# Altium schematic sheet FPGA_IO.SchDoc(FPGA_IO)
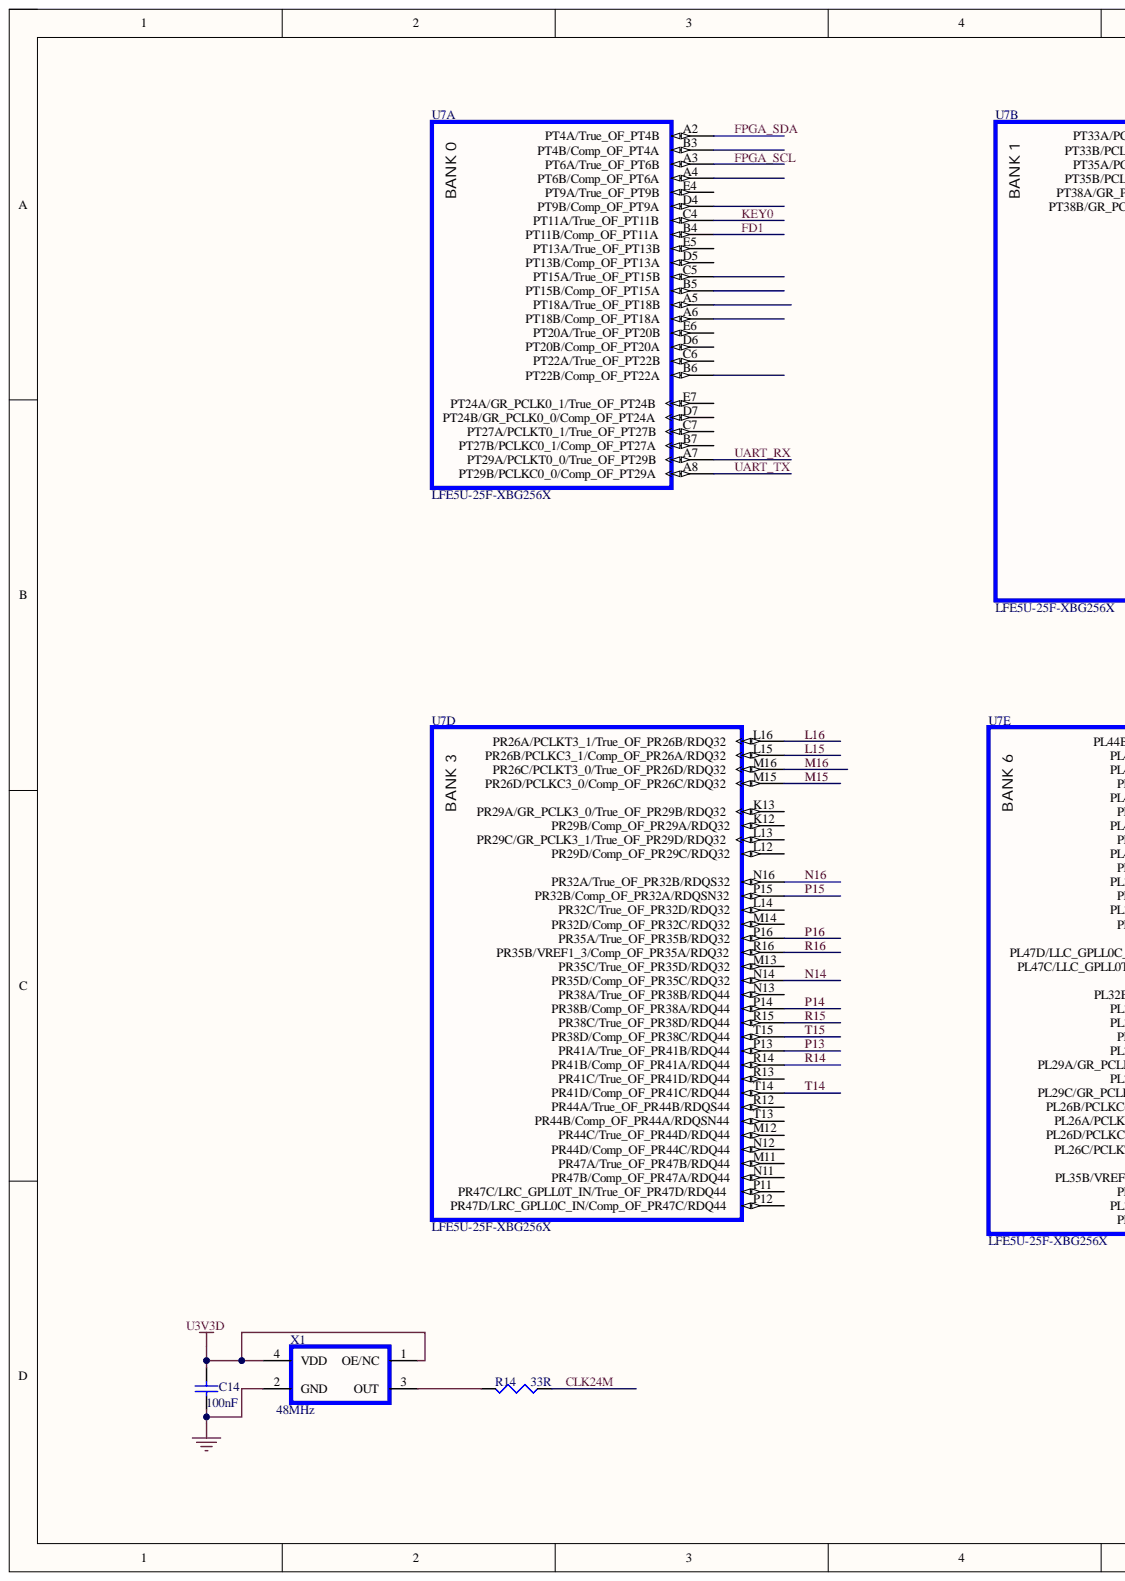
[diagram p0: FPGA_IO.SchDoc, left]
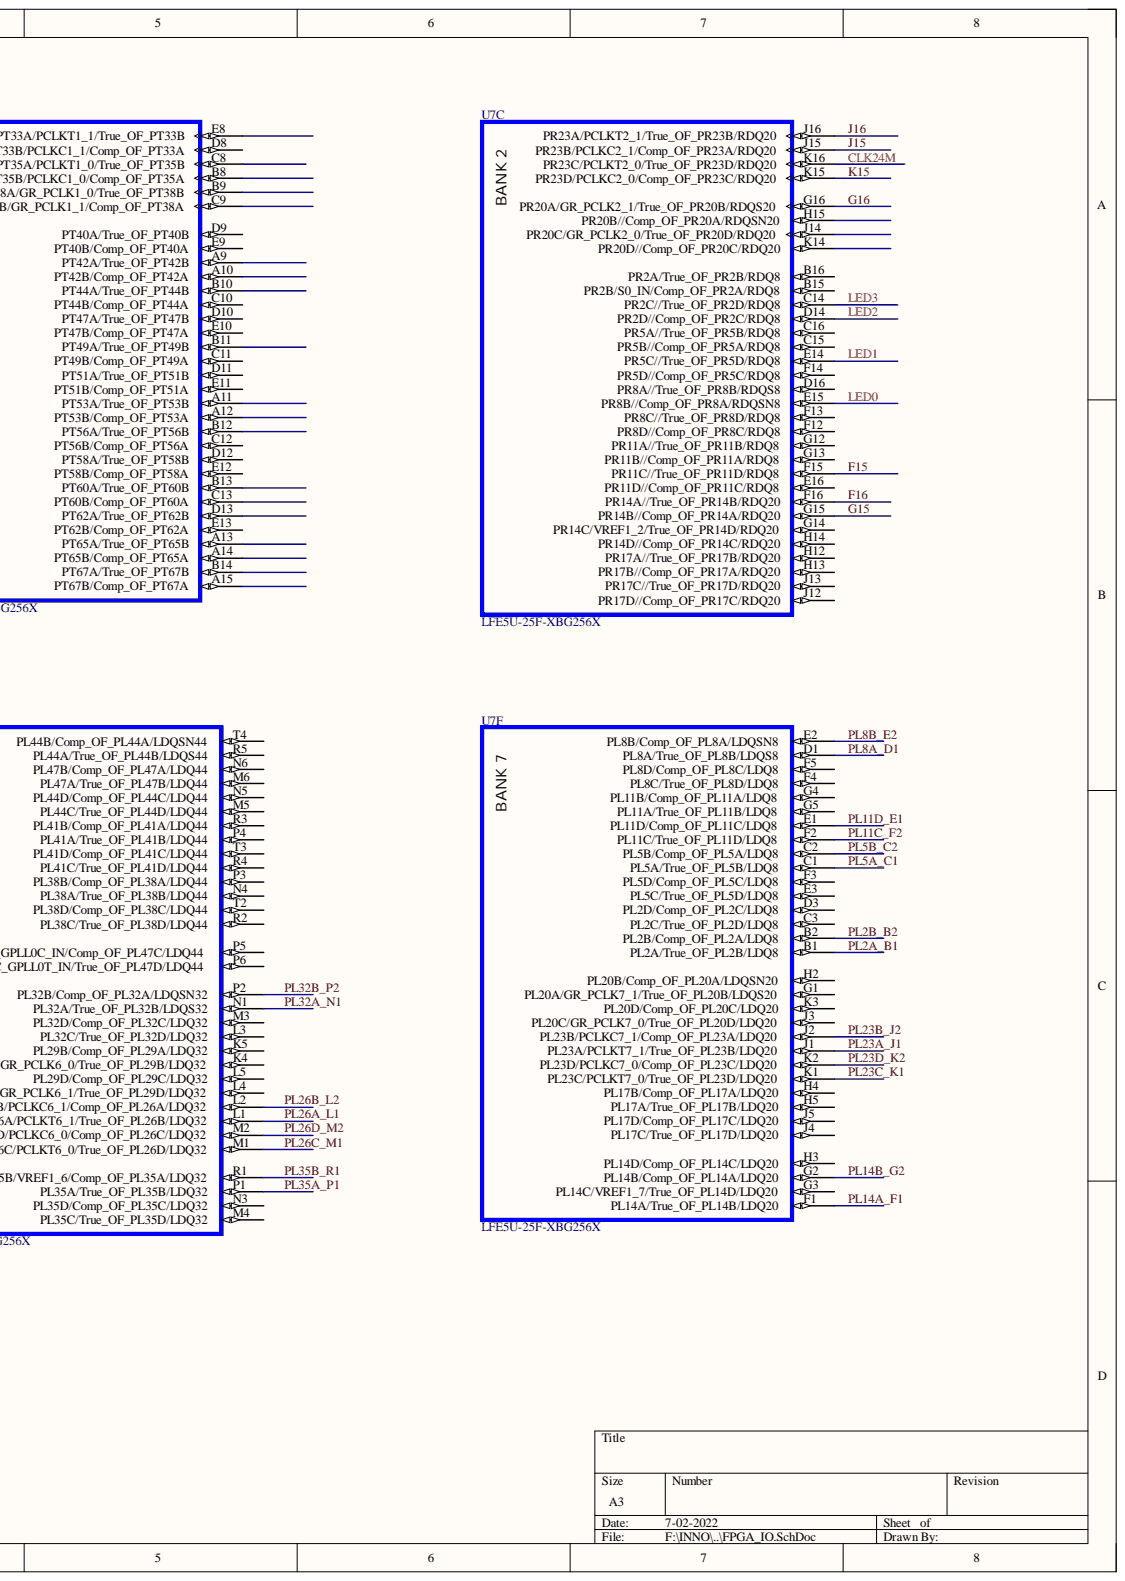
[diagram p1: FPGA_IO.SchDoc, right]

## Parts
C14  100nF  [0402-C]
R14  33R  [0402-R]
U7  LFE5U-25F-XBG256X  [caBGA256]  (parts A,B,C,D,E,F)
X1  48MHz  [3225]

## Nets
GND: C14.1 X1.2(GND)
U3V3D: C14.2 X1.1(OE/NC) X1.4(VDD)
CLK24M: R14.1 U7.K16(PR23C/PCLKT2_0/True_OF_PR23D/RDQ20)
F15: U7.F15(PR11C//True_OF_PR11D/RDQ8)
F16: U7.F16(PR14A//True_OF_PR14B/RDQ20)
FD1: U7.B4(PT11B/Comp_OF_PT11A)
FPGA_SCL: U7.A3(PT6A/True_OF_PT6B)
FPGA_SDA: U7.A2(PT4A/True_OF_PT4B)
G15: U7.G15(PR14B//Comp_OF_PR14A/RDQ20)
G16: U7.G16(PR20A/GR_PCLK2_1/True_OF_PR20B/RDQS20)
J15: U7.J15(PR23B/PCLKC2_1/Comp_OF_PR23A/RDQ20)
J16: U7.J16(PR23A/PCLKT2_1/True_OF_PR23B/RDQ20)
K15: U7.K15(PR23D/PCLKC2_0/Comp_OF_PR23C/RDQ20)
KEY0: U7.C4(PT11A/True_OF_PT11B)
L15: U7.L15(PR26B/PCLKC3_1/Comp_OF_PR26A/RDQ32)
L16: U7.L16(PR26A/PCLKT3_1/True_OF_PR26B/RDQ32)
LED0: U7.E15(PR8B//Comp_OF_PR8A/RDQSN8)
LED1: U7.E14(PR5C//True_OF_PR5D/RDQ8)
LED2: U7.D14(PR2D//Comp_OF_PR2C/RDQ8)
LED3: U7.C14(PR2C//True_OF_PR2D/RDQ8)
M15: U7.M15(PR26D/PCLKC3_0/Comp_OF_PR26C/RDQ32)
M16: U7.M16(PR26C/PCLKT3_0/True_OF_PR26D/RDQ32)
N14: U7.N14(PR35D/Comp_OF_PR35C/RDQ32)
N16: U7.N16(PR32A/True_OF_PR32B/RDQS32)
P13: U7.P13(PR41A/True_OF_PR41B/RDQ44)
P14: U7.P14(PR38B/Comp_OF_PR38A/RDQ44)
P15: U7.P15(PR32B/Comp_OF_PR32A/RDQSN32)
P16: U7.P16(PR35A/True_OF_PR35B/RDQ32)
PL2A_B1: U7.B1(PL2A/True_OF_PL2B/LDQ8)
PL2B_B2: U7.B2(PL2B/Comp_OF_PL2A/LDQ8)
PL5A_C1: U7.C1(PL5A/True_OF_PL5B/LDQ8)
PL5B_C2: U7.C2(PL5B/Comp_OF_PL5A/LDQ8)
PL8A_D1: U7.D1(PL8A/True_OF_PL8B/LDQS8)
PL8B_E2: U7.E2(PL8B/Comp_OF_PL8A/LDQSN8)
PL11C_F2: U7.F2(PL11C/True_OF_PL11D/LDQ8)
PL11D_E1: U7.E1(PL11D/Comp_OF_PL11C/LDQ8)
PL14A_F1: U7.F1(PL14A/True_OF_PL14B/LDQ20)
PL14B_G2: U7.G2(PL14B/Comp_OF_PL14A/LDQ20)
PL23A_J1: U7.J1(PL23A/PCLKT7_1/True_OF_PL23B/LDQ20)
PL23B_J2: U7.J2(PL23B/PCLKC7_1/Comp_OF_PL23A/LDQ20)
PL23C_K1: U7.K1(PL23C/PCLKT7_0/True_OF_PL23D/LDQ20)
PL23D_K2: U7.K2(PL23D/PCLKC7_0/Comp_OF_PL23C/LDQ20)
PL26A_L1: U7.L1(PL26A/PCLKT6_1/True_OF_PL26B/LDQ32)
PL26B_L2: U7.L2(PL26B/PCLKC6_1/Comp_OF_PL26A/LDQ32)
PL26C_M1: U7.M1(PL26C/PCLKT6_0/True_OF_PL26D/LDQ32)
PL26D_M2: U7.M2(PL26D/PCLKC6_0/Comp_OF_PL26C/LDQ32)
PL32A_N1: U7.N1(PL32A/True_OF_PL32B/LDQS32)
PL32B_P2: U7.P2(PL32B/Comp_OF_PL32A/LDQSN32)
PL35A_P1: U7.P1(PL35A/True_OF_PL35B/LDQ32)
PL35B_R1: U7.R1(PL35B/VREF1_6/Comp_OF_PL35A/LDQ32)
R14: U7.R14(PR41B/Comp_OF_PR41A/RDQ44)
R15: U7.R15(PR38C/True_OF_PR38D/RDQ44)
R16: U7.R16(PR35B/VREF1_3/Comp_OF_PR35A/RDQ32)
T14: U7.T14(PR41D/Comp_OF_PR41C/RDQ44)
T15: U7.T15(PR38D/Comp_OF_PR38C/RDQ44)
UART_RX: U7.A7(PT29A/PCLKT0_0/True_OF_PT29B)
UART_TX: U7.A8(PT29B/PCLKC0_0/Comp_OF_PT29A)
NetR14_2: R14.2 X1.3(OUT)

Not connected: U7 A4(PT6B/Comp_OF_PT6A) A5(PT18A/True_OF_PT18B) A6(PT18B/Comp_OF_PT18A) A9(PT42A/True_OF_PT42B) A10(PT42B/Comp_OF_PT42A) A11(PT53A/True_OF_PT53B) A12(PT53B/Comp_OF_PT53A) A13(PT65A/True_OF_PT65B) A14(PT65B/Comp_OF_PT65A) A15(PT67B/Comp_OF_PT67A) B3(PT4B/Comp_OF_PT4A) B5(PT15B/Comp_OF_PT15A) B6(PT22B/Comp_OF_PT22A) B7(PT27B/PCLKC0_1/Comp_OF_PT27A) B8(PT35B/PCLKC1_0/Comp_OF_PT35A) B9(PT38A/GR_PCLK1_0/True_OF_PT38B) B10(PT44A/True_OF_PT44B) B11(PT49A/True_OF_PT49B) B12(PT56A/True_OF_PT56B) B13(PT60A/True_OF_PT60B) B14(PT67A/True_OF_PT67B) B15(PR2B/S0_IN/Comp_OF_PR2A/RDQ8) B16(PR2A/True_OF_PR2B/RDQ8) C3(PL2C/True_OF_PL2D/LDQ8) C5(PT15A/True_OF_PT15B) C6(PT22A/True_OF_PT22B) C7(PT27A/PCLKT0_1/True_OF_PT27B) C8(PT35A/PCLKT1_0/True_OF_PT35B) C9(PT38B/GR_PCLK1_1/Comp_OF_PT38A) C10(PT44B/Comp_OF_PT44A) C11(PT49B/Comp_OF_PT49A) C12(PT56B/Comp_OF_PT56A) C13(PT60B/Comp_OF_PT60A) C15(PR5B//Comp_OF_PR5A/RDQ8) C16(PR5A//True_OF_PR5B/RDQ8) D3(PL2D/Comp_OF_PL2C/LDQ8) D4(PT9B/Comp_OF_PT9A) D5(PT13B/Comp_OF_PT13A) D6(PT20B/Comp_OF_PT20A) D7(PT24B/GR_PCLK0_0/Comp_OF_PT24A) D8(PT33B/PCLKC1_1/Comp_OF_PT33A) D9(PT40A/True_OF_PT40B) D10(PT47A/True_OF_PT47B) D11(PT51A/True_OF_PT51B) D12(PT58A/True_OF_PT58B) D13(PT62A/True_OF_PT62B) D16(PR8A//True_OF_PR8B/RDQS8) E3(PL5C/True_OF_PL5D/LDQ8) E4(PT9A/True_OF_PT9B) E5(PT13A/True_OF_PT13B) E6(PT20A/True_OF_PT20B) E7(PT24A/GR_PCLK0_1/True_OF_PT24B) E8(PT33A/PCLKT1_1/True_OF_PT33B) E9(PT40B/Comp_OF_PT40A) E10(PT47B/Comp_OF_PT47A) E11(PT51B/Comp_OF_PT51A) E12(PT58B/Comp_OF_PT58A) E13(PT62B/Comp_OF_PT62A) E16(PR11D//Comp_OF_PR11C/RDQ8) F3(PL5D/Comp_OF_PL5C/LDQ8) F4(PL8C/True_OF_PL8D/LDQ8) F5(PL8D/Comp_OF_PL8C/LDQ8) F12(PR8D//Comp_OF_PR8C/RDQ8) F13(PR8C//True_OF_PR8D/RDQ8) F14(PR5D//Comp_OF_PR5C/RDQ8) G1(PL20A/GR_PCLK7_1/True_OF_PL20B/LDQS20) G3(PL14C/VREF1_7/True_OF_PL14D/LDQ20) G4(PL11B/Comp_OF_PL11A/LDQ8) G5(PL11A/True_OF_PL11B/LDQ8) G12(PR11A//True_OF_PR11B/RDQ8) G13(PR11B//Comp_OF_PR11A/RDQ8) G14(PR14C/VREF1_2/True_OF_PR14D/RDQ20) H2(PL20B/Comp_OF_PL20A/LDQSN20) H3(PL14D/Comp_OF_PL14C/LDQ20) H4(PL17B/Comp_OF_PL17A/LDQ20) H5(PL17A/True_OF_PL17B/LDQ20) H12(PR17A//True_OF_PR17B/RDQ20) H13(PR17B//Comp_OF_PR17A/RDQ20) H14(PR14D//Comp_OF_PR14C/RDQ20) H15(PR20B//Comp_OF_PR20A/RDQSN20) J3(PL20C/GR_PCLK7_0/True_OF_PL20D/LDQ20) J4(PL17C/True_OF_PL17D/LDQ20) J5(PL17D/Comp_OF_PL17C/LDQ20) J12(PR17D//Comp_OF_PR17C/RDQ20) J13(PR17C//True_OF_PR17D/RDQ20) J14(PR20C/GR_PCLK2_0/True_OF_PR20D/RDQ20) K3(PL20D/Comp_OF_PL20C/LDQ20) K4(PL29A/GR_PCLK6_0/True_OF_PL29B/LDQ32) K5(PL29B/Comp_OF_PL29A/LDQ32) K12(PR29B/Comp_OF_PR29A/RDQ32) K13(PR29A/GR_PCLK3_0/True_OF_PR29B/RDQ32) K14(PR20D//Comp_OF_PR20C/RDQ20) L3(PL32C/True_OF_PL32D/LDQ32) L4(PL29C/GR_PCLK6_1/True_OF_PL29D/LDQ32) L5(PL29D/Comp_OF_PL29C/LDQ32) L12(PR29D/Comp_OF_PR29C/RDQ32) L13(PR29C/GR_PCLK3_1/True_OF_PR29D/RDQ32) L14(PR32C/True_OF_PR32D/RDQ32) M3(PL32D/Comp_OF_PL32C/LDQ32) M4(PL35C/True_OF_PL35D/LDQ32) M5(PL44C/True_OF_PL44D/LDQ44) M6(PL47A/True_OF_PL47B/LDQ44) M11(PR47A/True_OF_PR47B/RDQ44) M12(PR44C/True_OF_PR44D/RDQ44) M13(PR35C/True_OF_PR35D/RDQ32) M14(PR32D/Comp_OF_PR32C/RDQ32) N3(PL35D/Comp_OF_PL35C/LDQ32) N4(PL38A/True_OF_PL38B/LDQ44) N5(PL44D/Comp_OF_PL44C/LDQ44) N6(PL47B/Comp_OF_PL47A/LDQ44) N11(PR47B/Comp_OF_PR47A/RDQ44) N12(PR44D/Comp_OF_PR44C/RDQ44) N13(PR38A/True_OF_PR38B/RDQ44) P3(PL38B/Comp_OF_PL38A/LDQ44) P4(PL41A/True_OF_PL41B/LDQ44) P5(PL47D/LLC_GPLL0C_IN/Comp_OF_PL47C/LDQ44) P6(PL47C/LLC_GPLL0T_IN/True_OF_PL47D/LDQ44) P11(PR47C/LRC_GPLL0T_IN/True_OF_PR47D/RDQ44) P12(PR47D/LRC_GPLL0C_IN/Comp_OF_PR47C/RDQ44) R2(PL38C/True_OF_PL38D/LDQ44) R3(PL41B/Comp_OF_PL41A/LDQ44) R4(PL41C/True_OF_PL41D/LDQ44) R5(PL44A/True_OF_PL44B/LDQS44) R12(PR44A/True_OF_PR44B/RDQS44) R13(PR41C/True_OF_PR41D/RDQ44) T2(PL38D/Comp_OF_PL38C/LDQ44) T3(PL41D/Comp_OF_PL41C/LDQ44) T4(PL44B/Comp_OF_PL44A/LDQSN44) T13(PR44B/Comp_OF_PR44A/RDQSN44)

## Library data of the parts
C14 = Cap Semi: Capacitor (Semiconductor SIM Model); Component Kind: Standard; Library Name: YuanQi.SchLib
R14 = RES: 电阻; Component Kind: Standard; Library Name: YuanQi.SchLib
U7 = LFE5U-25F-XBG256X: Lattice ECP FPGA，兼容LFE5U-45F-XBG256X; Component Kind: Standard; PackageVersion: Rev 1.1; RoHS: Pb-Free; Library Name: YuanQi.SchLib
X1 = XTAL-SITIME: 有源晶振; Component Kind: Standard; Library Name: YuanQi.SchLib
